# Altium schematic sheet Sheet2.SchDoc(Sheet2)
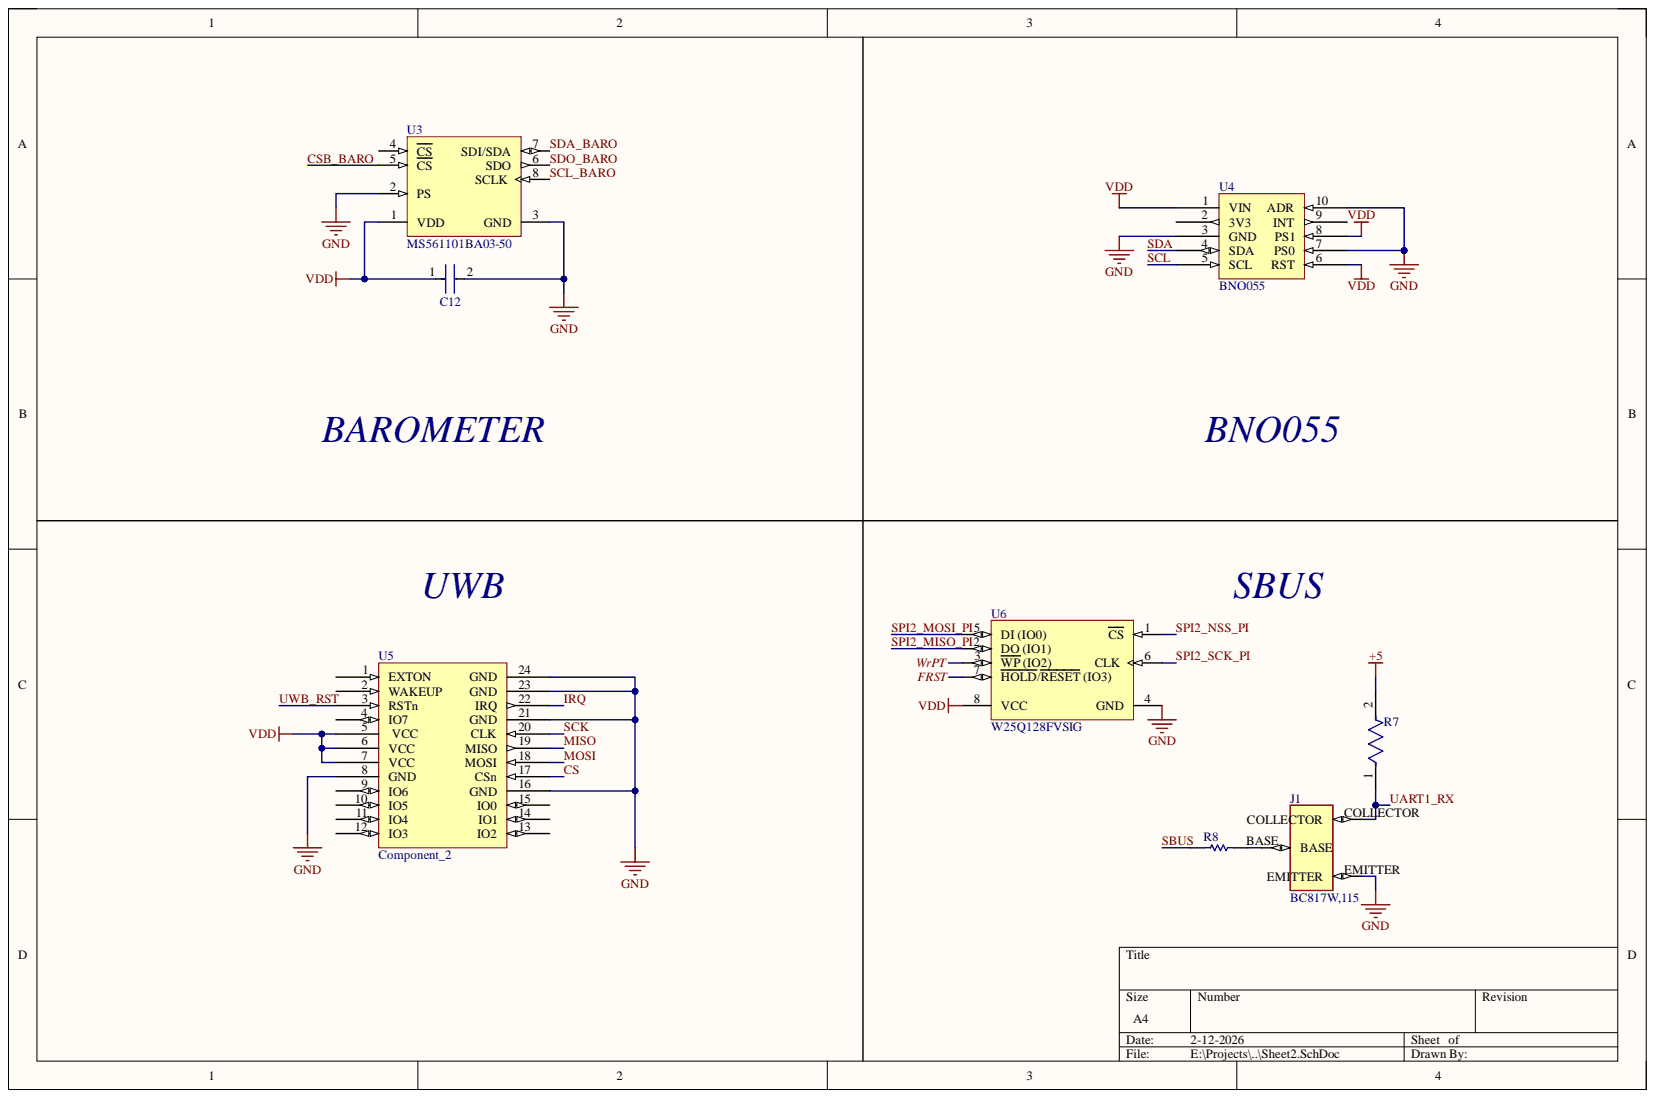
[diagram p0: Sheet2.SchDoc]

## Parts
C12  C0603C104K4RACTU  [FP-C0603C-CF-MFG]  (value not drawn)
J1  BC817W,115  [SOT323]
R7  MCT06030C1002FP500  [FP-MCT0603-IPC_C]  (value not drawn)
R8  RC0603JR-074K7L  [RESC1608X55X25ML10T15]  (value not drawn)
U3  MS561101BA03-50  [MSI-MS561101BA03-50-8_V]
U4  BNO055  [BNO055_MOD]
U5  Component_2  [BU01]
U6  W25Q128FVSIG  [WINB-SOIC-8_M]

## Nets
+5: R7.2
GND: C12.2 J1.EMITTER U3.2(PS) U3.3(GND) U4.3(GND) U4.7(PS0) U4.10(ADR) U5.8(GND) U5.16(GND) U5.21(GND) U5.23(GND) U5.24(GND) U6.4(GND)
VDD: C12.1 U3.1(VDD) U4.1(VIN) U4.6(RST) U4.8(PS1) U5.5(VCC) U5.6(VCC) U5.7(VCC) U6.8(VCC)
CS: U5.17(CSn)
CSB_BARO: U3.5(CS)
FRST: U6.7(HOLD/RESET_(IO3))
IRQ: U5.22(IRQ)
MISO: U5.19(MISO)
MOSI: U5.18(MOSI)
SBUS: R8.1
SCK: U5.20(CLK)
SCL: U4.5(SCL)
SCL_BARO: U3.8(SCLK)
SDA: U4.4(SDA)
SDA_BARO: U3.7(SDI/SDA)
SDO_BARO: U3.6(SDO)
SPI2_MISO_PI: U6.2(DO_(IO1))
SPI2_MOSI_PI: U6.5(DI_(IO0))
SPI2_NSS_PI: U6.1(CS)
SPI2_SCK_PI: U6.6(CLK)
UART1_RX: J1.COLLECTOR R7.1
UWB_RST: U5.3(RSTn)
WrPT: U6.3(WP_(IO2))
NetJ1_BASE: J1.BASE R8.2

Not connected: U3 4(CS); U4 2(3V3) 9(INT); U5 1(EXTON) 2(WAKEUP) 4(IO7) 9(IO6) 10(IO5) 11(IO4) 12(IO3) 13(IO2) 14(IO1) 15(IO0)

## Library data of the parts
C12 = CMP-2006-03811-3: Capacitor; Ceramic; Cap; .100uF; Tol 10%; SMT; Vol-Rtg 16V; X7R; Tape and Reel; Manufacturer: KEMET; Automotive Grade: Grade 1; Capacitance: 0.10 μF; Temperature Coefficient: 30ppm/°C; Tolerance: 0.1; Value: 0.10 μF; Automotive: Yes; Category: Cap; Component Kind: Standard; ComponentLink2Description: Datasheet; ComponentLink2URL: https://api.kemet.com/component-edge/download/datasheet/C0603C100J5GACAUTO.pdf; Device Class L1: Passive Components; Device Class L2: Capacitors; Device Class L3: Ceramic Capacitors; Digikey Description: Capacitor; Ceramic; Cap; .100uF; Tol 10%; SMT; Vol-Rtg 16V; X7R; Tape and Reel; Height: 0.87mm; Lead Free: Yes; Library Name: Altium Content Vault; Manufacturer Part Number: C0603C104K4RACTU; Material: Ceramic; Mouser Description: Multilayer Ceramic Capacitors MLCC - SMD/SMT 16volts 0.1uF 10% X7R 10%; Octopart: https://octopart.com/c0603c104k4ractu-kemet-93067; Package: C0603C-CF; RoHS: TRUE; Temperature: -55°C to +125°C; Temperature Characteristic: X7R; Temperature Range High: +125°C; Temperature Range Low: -55°C; Voltage Rating: 16 V
J1 = BC817W,115: Bipolar (BJT) Transistor NPN 45 V 500 mA 100MHz 200 mW Surface Mount SOT-323; MF: Nexperia USA; Availability: In Stock; Check_prices: https://www.snapeda.com/parts/BC817W,115/Nexperia/view-part/?ref=eda; Component Kind: Standard; Library Name: BC817W,115.SchLib; MP: BC817W,115; Package: SC-70-3 Nexperia USA Inc.; Price: None; Purchase-URL: https://pricing.snapeda.com/search/part/BC817W,115/?ref=eda; SnapEDA_Link: https://www.snapeda.com/parts/BC817W,115/Nexperia/view-part/?ref=snap
R7 = CMP-02407-000418-1: RES SMD 100 OHM 1% 1/8W 0603; Max Power Dissipation: 125mW; Power Rating: 100mW; Resistance: 10kR; Temperature Coefficient: 50ppm/°C; Voltage Rating: 75V; Case Code (Imperial): 0603; Case Code (Metric): 1608; Case/Package: 0603; Component Kind: Standard; Composition: Thin Film; Contact Plating: Tin; Depth: 0.85mm; Height: 0.022inch; Lead Free: Lead Free; Length: 1.55mm; Library Name: Altium Content Vault; Max Operating Temperature: 125°C; Military Standard: Not; Min Operating Temperature: -55°C; Mount: Surface Mount; Number of Terminations: 2; Package Quantity: 1; Packaging: Tape and Reel; Radiation Hardening: No; Tolerance: 1%; Voltage Rating (DC): 75V; Width: 0.8mm
R8 = CMP-2000-05024-1: Chip Resistor, 4.7 KOhm, +/- 5%, 100 mW, -55 to 155 degC, 0603 (1608 Metric), RoHS, Tape and Reel; Manufacturer: Yageo; Power Rating: 100mW; Resistance: 4.7kOhms; Temperature Coefficient: 100PPM/C; Voltage Rating: 50V; Case Code - in: 0603; Case Code - mm: 1608; Component Kind: Standard; Datasheet URL: http://www.yageo.com/documents/recent/PYu-RC_Group_51_RoHS_L_04.pdf; Datasheet Version: Rev. 4, 02/2016; Library Name: Altium Content Vault; Manufacturer URL: http://www.yageo.com/; Operating Temperature Range: -55Cto+155C; Package Description: 2-Pin Surface Mount Device, Body 1.6 x 0.8 mm; Package Reference: 603; Packaging: Reel; Product: ThickFilmResistorsGeneralPurpose; Series: RC; Termination Style: SMD/SMT; Tolerance: 5%
U3 = CMP-2000-05251-1: Barometric Pressure Sensor, with Stainless Steel Cap, 1.8 to 3.6 V, -45 to 85 degC, 8-Pin QFN, RoHS, Tape and Reel; Manufacturer: Measurement Specialties; Connection Size: 0.5mm; No. of Pins: 8; Operating Pressure Max: 1200mbar; Operating Pressure Min: 10mbar; Supply Current: 12.5µA; Supply Voltage Max: 3.6V; Supply Voltage Min: 1.8V; SVHC: NoSVHC(20-Jun-2013); Component Kind: Standard; Datasheet URL: http://www.te.com/commerce/DocumentDelivery/DDEController?Action=srchrtrv&DocNm=MS5611-01BA03&DocType=Data+Sheet&DocLang=English&DocFormat=pdf&PartCntxt=CAT-BLPS0036; Datasheet Version: Sep-15; Library Name: Altium Content Vault; Manufacturer URL: http://www.meas-spec.com/; Operating Temperature Max: 85degC; Operating Temperature Min: -40degC; Package Description: 8-Pin Surface Mount Device, Body 5 x 3 mm, Pitch 1.25 mm; Package Reference: MS561101BA03-50-8; Pressure Type: Barometric; Sensor Case Style: QFN
U4 = Component_1: Component Kind: Standard; Library Name: BNO055.SchLib
U6 = CMP-2000-05005-1: 3V 128M-Bit Serial Flash Memory with Dual/Quad SPI & QPI, 2.7 to 3.6 V, -40 to 85 degC, 8-Pin SOIC, RoHS, Tape and Reel; Manufacturer: Winbond; Access Time (Max): 7ns; Address Bus: 24b; Boot Type: NotRequired; Cell Type: NOR; Density: 134217728Bit; Interface Type: Serial(SPI); Number of Words: 16777216; Operating Supply Voltage (Max): 3.6V; Operating Supply Voltage (Min): 2.7V; Operating Supply Voltage (Typ): 3/3.3V; Programmable: Yes; Rad Hardened: No; Supply Current: 20mA; Sync/Async: Synchronous; Word Size: 8b; Component Kind: Standard; Datasheet URL: http://download.siliconexpert.com/pdfs/2015/11/30/6/42/17/890/win_/manual/3w25q128fv20rev.l2008242015.pdf; Datasheet Version: Rev. L, 08/2015; Library Name: Altium Content Vault; Manufacturer URL: http://www.winbond.com/hq/index.html?__locale=en; Mounting: SurfaceMount; Operating Temp Range: -40Cto85C; Operating Temperature (Max): 85C; Operating Temperature (Min): -40C; Operating Temperature Classification: Industrial; Package Description: 8-Pin Small Outline Integrated Circuit, Body 5.28 x 5.28 mm, Pitch 1.27 mm; Package Reference: SS-8; Package Type: SOICW; Pin Count: 8; Program/Erase Volt (Typ): 2.7to3.6V
U5 = Component_2: Component Kind: Standard; Library Name: BU01 UWB.SchLib
